# Altium schematic sheet DDR_HDMI.SchDoc(DDR_HDMI)
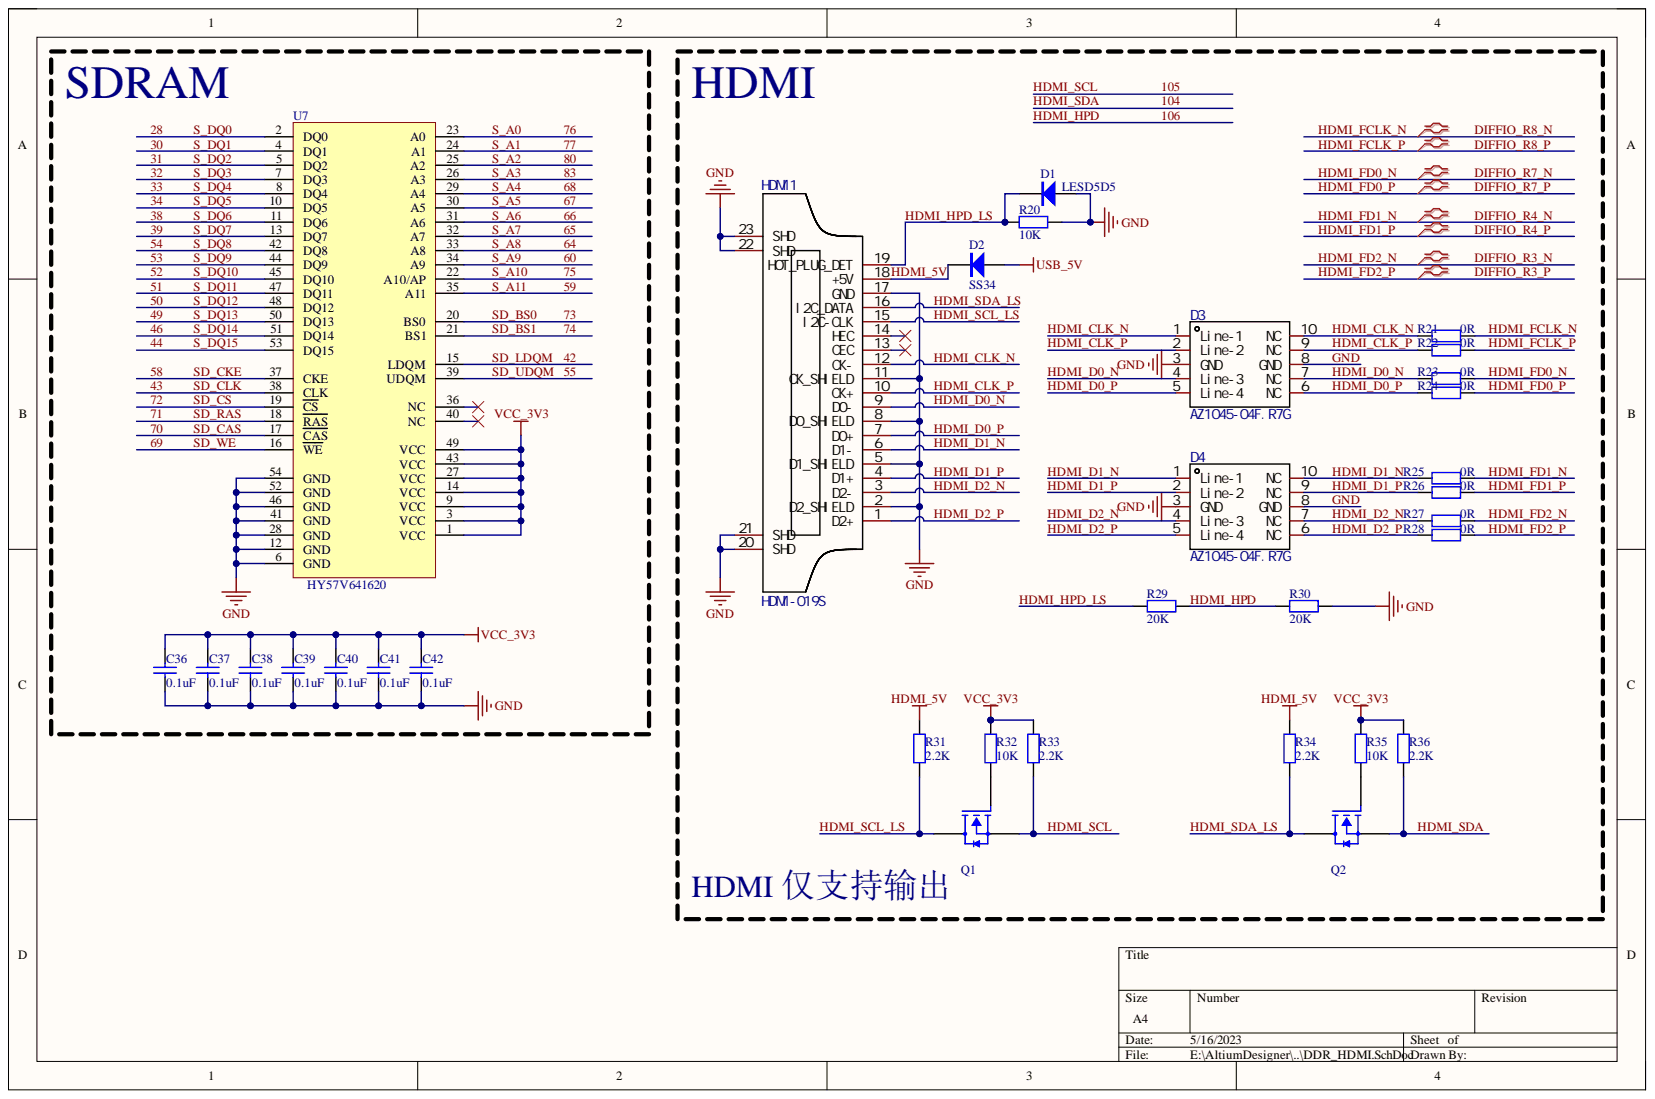
[diagram p0: DDR_HDMI.SchDoc]

## Parts
C36  0.1uF  [0402_C]
C37  0.1uF  [0402_C]
C38  0.1uF  [0402_C]
C39  0.1uF  [0402_C]
C40  0.1uF  [0402_C]
C41  0.1uF  [0402_C]
C42  0.1uF  [0402_C]
D1  LESD5D5  [SOD-523_L1.2-W0.8-LS1.6-RD]
D2  SS34  [SMC]
D3  AZ1045-04F.R7G  [DFN2510-10_L2.5-W1.0-P0.50-BL]
D4  AZ1045-04F.R7G  [DFN2510-10_L2.5-W1.0-P0.50-BL]
HDMI1  HDMI-019S  [HDMI-SMD_HDMI-019S]
Q1  AO3400A  [SOT23-3]  (value not drawn)
Q2  AO3400A  [SOT23-3]  (value not drawn)
R20  10K  [0402_R]
R21  0R  [0402_R]
R22  0R  [0402_R]
R23  0R  [0402_R]
R24  0R  [0402_R]
R25  0R  [0402_R]
R26  0R  [0402_R]
R27  0R  [0402_R]
R28  0R  [0402_R]
R29  20K  [0402_R]
R30  20K  [0402_R]
R31  2.2K  [0402_R]
R32  10K  [0402_R]
R33  2.2K  [0402_R]
R34  2.2K  [0402_R]
R35  10K  [0402_R]
R36  2.2K  [0402_R]
U7  HY57V641620  [TSOP-54_L22.2-W10.2-P0.80-LS11.8-BL]

## Nets
USB_5V: D2.1
VCC_3V3: C36.2 C37.2 C38.2 C39.2 C40.2 C41.2 C42.2 R32.2 R33.2 R35.2 R36.2 U7.1(VCC) U7.3(VCC) U7.9(VCC) U7.14(VCC) U7.27(VCC) U7.43(VCC) U7.49(VCC)
28: U7.2(DQ0)
30: U7.4(DQ1)
31: U7.5(DQ2)
32: U7.7(DQ3)
33: U7.8(DQ4)
34: U7.10(DQ5)
38: U7.11(DQ6)
39: U7.13(DQ7)
42: U7.15(LDQM)
43: U7.38(CLK)
44: U7.53(DQ15)
46: U7.51(DQ14)
49: U7.50(DQ13)
50: U7.48(DQ12)
51: U7.47(DQ11)
52: U7.45(DQ10)
53: U7.44(DQ9)
54: U7.42(DQ8)
55: U7.39(UDQM)
58: U7.37(CKE)
59: U7.35(A11)
60: U7.34(A9)
64: U7.33(A8)
65: U7.32(A7)
66: U7.31(A6)
67: U7.30(A5)
68: U7.29(A4)
69: U7.16(WE)
70: U7.17(CAS)
71: U7.18(RAS)
72: U7.19(CS)
73: U7.20(BS0)
74: U7.21(BS1)
75: U7.22(A10/AP)
76: U7.23(A0)
77: U7.24(A1)
80: U7.25(A2)
83: U7.26(A3)
104: Q2.2 R36.1
105: Q1.2 R33.1
106: R29.2 R30.1
DIFFIO_R3_N: R27.2
DIFFIO_R3_P: R28.2
DIFFIO_R4_N: R25.2
DIFFIO_R4_P: R26.2
DIFFIO_R7_N: R23.2
DIFFIO_R7_P: R24.2
DIFFIO_R8_N: R21.2
DIFFIO_R8_P: R22.2
GND: C36.1 C37.1 C38.1 C39.1 C40.1 C41.1 C42.1 D1.1 D3.3(GND) D3.8(GND) D4.3(GND) D4.8(GND) HDMI1.2(D2_SHIELD) HDMI1.5(D1_SHIELD) HDMI1.8(D0_SHIELD) HDMI1.11(CK_SHIELD) HDMI1.17(GND) HDMI1.20(SHD) HDMI1.21(SHD) HDMI1.22(SHD) HDMI1.23(SHD) R20.2 R30.2 U7.6(GND) U7.12(GND) U7.28(GND) U7.41(GND) U7.46(GND) U7.52(GND) U7.54(GND)
HDMI_5V: D2.2 HDMI1.18(+5V) R31.2 R34.2
HDMI_CLK_N: D3.1(Line-1) D3.10(NC) HDMI1.12(CK-) R21.1
HDMI_CLK_P: D3.2(Line-2) D3.9(NC) HDMI1.10(CK+) R22.1
HDMI_D0_N: D3.4(Line-3) D3.7(NC) HDMI1.9(D0-) R23.1
HDMI_D0_P: D3.5(Line-4) D3.6(NC) HDMI1.7(D0+) R24.1
HDMI_D1_N: D4.1(Line-1) D4.10(NC) HDMI1.6(D1-) R25.1
HDMI_D1_P: D4.2(Line-2) D4.9(NC) HDMI1.4(D1+) R26.1
HDMI_D2_N: D4.4(Line-3) D4.7(NC) HDMI1.3(D2-) R27.1
HDMI_D2_P: D4.5(Line-4) D4.6(NC) HDMI1.1(D2+) R28.1
HDMI_HPD_LS: D1.2 HDMI1.19(HOT_PLUG_DET) R20.1 R29.1
HDMI_SCL_LS: HDMI1.15(I2C-CLK) Q1.3 R31.1
HDMI_SDA_LS: HDMI1.16(I2C_DATA) Q2.3 R34.1
NetQ1_1: Q1.1 R32.1
NetQ2_1: Q2.1 R35.1

Not connected: HDMI1 13(CEC) 14(HEC); U7 36(NC) 40(NC)

## Library data of the parts
C36-C42 = 0402_C: 贴片电容; Component Kind: Standard; ComponentLink1Description: 供应商链接; ComponentLink1URL: http://www.szlcsc.com/product/details_1928.html; Library Name: My_Devices.IntLib; Package: 0402; Supplier: LC; SuppliersPartNumber: C1576
D1 = 1N5819: 肖特基二极管; 属性: 40V/2A; 版权归属: 源创客; BOM名称: 肖特基二极管; Component Kind: Standard; Library Name: My_Devices.IntLib; 学习资源: www.ooocke.com
D2 = SS34: 肖特基二极管; 属性: 40V/3A; 版权归属: 源创客; BOM名称: 肖特基二极管; Component Kind: Standard; Library Name: My_Devices.IntLib; 学习资源: www.ooocke.com
D3 D4 = AZ1045-04F.R7G: 反向截止电压(Vrwm):5V;击穿电压:6V;最大钳位电压:12V;; Manufacturer: AMAZING(晶焱); Add into BOM: yes; Breakdown Voltage: 6V; Maximum Clamping Voltage: 12V; Peak Pulse Current (Ipp)@10/1000μs: 3.5A@8/20us; Reverse Stand-Off Voltage (Vrwm): 5V; Component Kind: Standard; Convert to PCB: yes; Datasheet: https://atta.szlcsc.com/upload/public/pdf/source/20190802/C356811_0B9EAE80F3B7F983A0CAF91AEAB45A28.pdf; Device: AZ1045-04F.R7G; JLCPCB Part Class: Extended Part; LCSC Part Name: AZ1045-04F.R7G; Library Name: Schlib1.SchLib; Manufacturer Part: AZ1045-04F.R7G; Name: AZ1045-04F.R7G; Supplier: LCSC; Supplier Footprint: DFN-10(1x2.5); Supplier Part: C356811; Symbol: AZ1045-04F.R7G
HDMI1 = HDMI-019S: 连接器类型:HDMI;公母:母;总PIN位数:19;排数:-;安装方式:卧贴;额定电流:500mA;工作温度范围:-25℃~+75℃;; Manufacturer: SOFNG(硕方); Add into BOM: yes; Connector Type: HDMI; Current Rating (Max): 500mA; Gender: 母; Component Kind: Standard; Convert to PCB: yes; Datasheet: https://atta.szlcsc.com/upload/public/pdf/source/20200911/C111617_84DD1BD35B9EB8A28224A63520745D0A.pdf; Device: HDMI-019S; JLCPCB Part Class: Extended Part; LCSC Part Name: HDMI 母 P数:19; Library Name: Schlib1.SchLib; Manufacturer Part: HDMI-019S; Mounting Style: 卧贴; Name: HDMI-019S; Number of Pins: 19; Number of Rows: -; Operating Temperature Range: -25℃~+75℃; Supplier: LCSC; Supplier Footprint: SMD; Supplier Part: C111617; Symbol: HDMI-019S
Q1 Q2 = AO3400A: N-MOS; Component Kind: Standard; Library Name: Schlib1.SchLib
R20-R36 = 0402_R: 贴片电阻; Component Kind: Standard; ComponentLink1Description: 供应商链接; ComponentLink1URL: http://www.szlcsc.com/product/details_26667.html; Library Name: My_Devices.IntLib; Package: 0402; Supplier: LC; SuppliersPartNumber: C25924
U7 = HY57V641620: Component Kind: Standard; Description: HY57V641620; Library Name: Schlib1.SchLib
